# Altium schematic sheet Sheet1.SchDoc
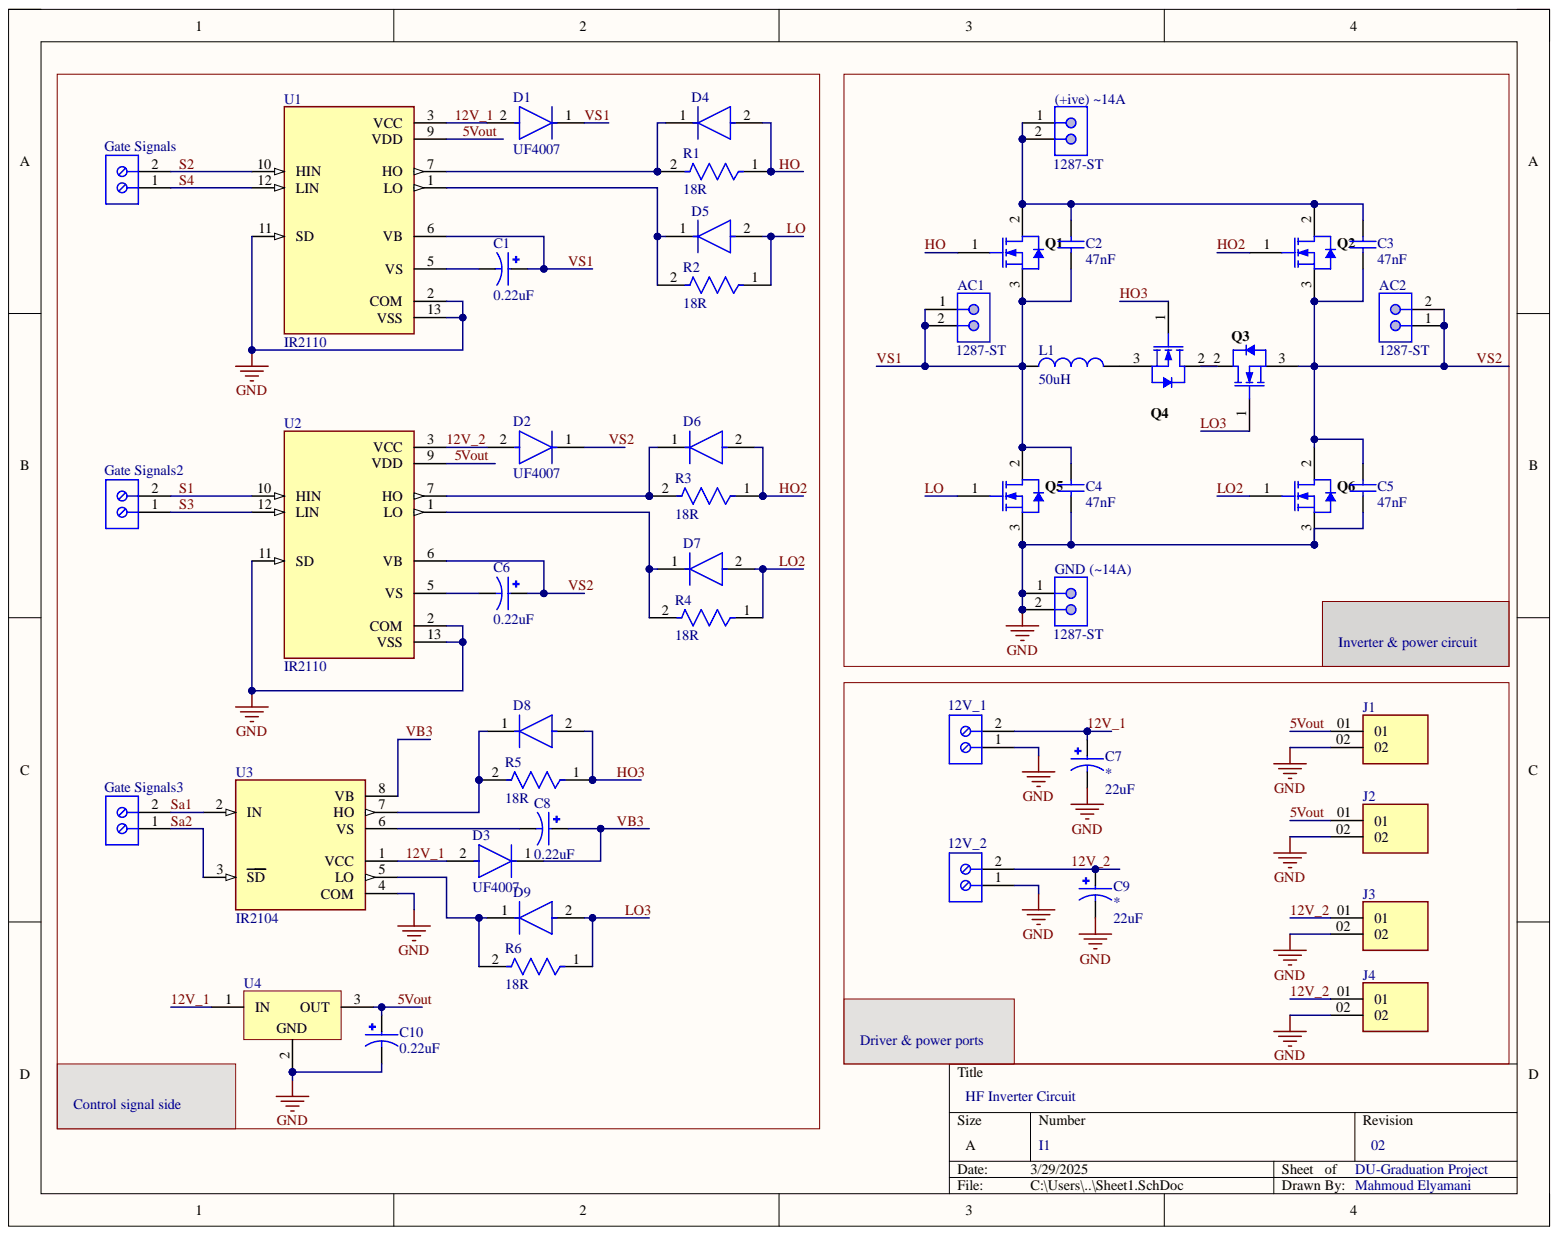
[diagram p0: Sheet1.SchDoc]

## Parts
12V_1  691213710002  [691213710002]  (value not drawn)
12V_2  691213710002  [691213710002]  (value not drawn)
(+ive)_~14A  1287-ST  [KSTN-1287-ST]
AC1  1287-ST  [KSTN-1287-ST]
AC2  1287-ST  [KSTN-1287-ST]
C1  value 0.22uF  [CAPPRD250W50D500H1100]  (hidden comment: EEUHD1HR22H)
C2  47nF  [WCAP-FTX2_L11W6H10_CS]
C3  47nF  [WCAP-FTX2_L11W6H10_CS]
C4  47nF  [WCAP-FTX2_L11W6H10_CS]
C5  47nF  [WCAP-FTX2_L11W6H10_CS]
C6  value 0.22uF  [CAPPRD250W50D500H1100]  (hidden comment: EEUHD1HR22H)
C7  22uF  [CAPPRD500W60D1000H2000]
C8  value 0.22uF  [CAPPRD250W50D500H1100]  (hidden comment: EEUHD1HR22H)
C9  22uF  [CAPPRD500W60D1000H2000]
C10  value 0.22uF  [CAPPRD250W50D500H1100]  (hidden comment: EEUHD1HR22H)
D1  UF4007  [FP-017AH-MFG]
D2  UF4007  [FP-017AH-MFG]
D3  UF4007  [FP-017AH-MFG]
D4  UF4007  [FP-017AH-MFG]  (value not drawn)
D5  UF4007  [FP-017AH-MFG]  (value not drawn)
D6  UF4007  [FP-017AH-MFG]  (value not drawn)
D7  UF4007  [FP-017AH-MFG]  (value not drawn)
D8  UF4007  [FP-017AH-MFG]  (value not drawn)
D9  UF4007  [FP-017AH-MFG]
Gate_Signals  691213710002  [691213710002]  (value not drawn)
Gate_Signals2  691213710002  [691213710002]  (value not drawn)
Gate_Signals3  691213710002  [691213710002]  (value not drawn)
GND_(~14A)  1287-ST  [KSTN-1287-ST]
J1  MTMM-102-04-T-S-170  [SAMTEC_MTMM-102-04-T-S-170]  (value not drawn)
J2  MTMM-102-04-T-S-170  [SAMTEC_MTMM-102-04-T-S-170]  (value not drawn)
J3  MTMM-102-04-T-S-170  [SAMTEC_MTMM-102-04-T-S-170]  (value not drawn)
J4  MTMM-102-04-T-S-170  [SAMTEC_MTMM-102-04-T-S-170]  (value not drawn)
L1  50uH  [7447071]
Q1  IRFP460PBF  [VISH-TO-247AC-3]  (value not drawn)
Q2  IRFP460PBF  [VISH-TO-247AC-3]  (value not drawn)
Q3  IRFP460PBF  [VISH-TO-247AC-3]  (value not drawn)
Q4  IRFP460PBF  [VISH-TO-247AC-3]  (value not drawn)
Q5  IRFP460PBF  [VISH-TO-247AC-3]  (value not drawn)
Q6  IRFP460PBF  [VISH-TO-247AC-3]  (value not drawn)
R1  18R  [FP-VR37-MFG]
R2  18R  [FP-VR37-MFG]
R3  18R  [FP-VR37-MFG]
R4  18R  [FP-VR37-MFG]
R5  18R  [FP-VR37-MFG]
R6  18R  [FP-VR37-MFG]
U1  IR2110  [DIP762W45P254L2019H533Q14]
U2  IR2110  [DIP762W45P254L2019H533Q14]
U3  IR2104  [DIP762W45P254L988H533Q8]
U4  L7824ABV  [TO220]  (value not drawn)

## Nets
GND: 12V_1.1 12V_2.1 C4.1 C5.1 C7.N C9.N C10.N GND_(~14A).1 GND_(~14A).2 J1.02 J2.02 J3.02 J4.02 Q5.3 Q6.3 U1.2(COM) U1.11(SD) U1.13(VSS) U2.2(COM) U2.11(SD) U2.13(VSS) U3.4(COM) U4.2
5Vout: C10.P J1.01 J2.01 U1.9(VDD) U2.9(VDD) U4.3
12V_1: 12V_1.2 C7.P D1.2 D3.2 U1.3(VCC) U3.1(VCC) U4.1
12V_2: 12V_2.2 C9.P D2.2 J3.01 J4.01 U2.3(VCC)
HO: D4.2 Q1.1 R1.1
HO2: D6.2 Q2.1 R3.1
HO3: D8.2 Q4.1 R5.1
LO: D5.2 Q5.1 R2.1
LO2: D7.2 Q6.1 R4.1
LO3: D9.2 Q3.1 R6.1
S1: Gate_Signals2.2 U2.10(HIN)
S2: Gate_Signals.2 U1.10(HIN)
S3: Gate_Signals2.1 U2.12(LIN)
S4: Gate_Signals.1 U1.12(LIN)
Sa1: Gate_Signals3.2 U3.2(IN)
Sa2: Gate_Signals3.1 U3.3(SD)
VB3: C8.P D3.1 U3.8(VB)
VS1: AC1.1 AC1.2 C1.P C2.1 C4.2 D1.1 L1.1 Q1.3 Q5.2 U1.6(VB)
VS2: AC2.1 AC2.2 C3.1 C5.2 C6.P D2.1 Q2.3 Q3.3 Q6.2 U2.6(VB)
Net(+ive) ~14A_1: (+ive)_~14A.1 (+ive)_~14A.2 C2.2 C3.2 Q1.2 Q2.2
NetC1_N: C1.N U1.5(VS)
NetC6_N: C6.N U2.5(VS)
NetC8_N: C8.N U3.6(VS)
NetD4_1: D4.1 R1.2 U1.7(HO)
NetD5_1: D5.1 R2.2 U1.1(LO)
NetD6_1: D6.1 R3.2 U2.7(HO)
NetD7_1: D7.1 R4.2 U2.1(LO)
NetD8_1: D8.1 R5.2 U3.7(HO)
NetD9_1: D9.1 R6.2 U3.5(LO)
NetL1_2: L1.2 Q4.3
NetQ3_2: Q3.2 Q4.2

## Library data of the parts
12V_1 12V_2 Gate_Signals Gate_Signals2 Gate_Signals3 = CMP-1502-02526-2: NexarPartId: 8917718; Current: 10A; Number of Contacts: 2; Number of Levels: 1; Pitch: 5.0038mm; Plating: Brass, Steel; Stripping Length: -7mm; Voltage: 300V; Wire Gauge (Max): 26AWG; Wire Gauge (Min): 16AWG; Color: Green; Component Kind: Standard; Contact Material: Brass; Contact Plating: Tin; Current Rating: 10A; Height: 10mm; Housing Material: Nylon; Lead Pitch: 5mm; Length: 8.3mm; Library Name: Altium Content Vault; Max Operating Temperature: 105°C; Min Operating Temperature: -40°C; Mount: Through Hole; Number of Pins: 2; Number of Positions Per Level: 2; Packaging: Box; REACH SVHC: Unknown; RoHS: Compliant; Termination: Screw; Voltage Rating: 300V; Width: 10.6mm; Wire/Cable Gauge: 26AWG
(+ive)_~14A AC1 AC2 GND_(~14A) = CMP-2000-05485-1: PC Sturdi-Mount Quick-Fit Terminal, Vertical, Male, 2-Pin THD, Bulk; Manufacturer: Keystone; Angle: Straight; Finish: Tin; Finish Plating: TinPlate; Insulation Type: Non-Insulated; Material, Contact: Brass; Mounting Hole Size: 0.052; Primary Type: QuickDisconnect; Standards: ULListed; Tab Fit: 0.25; Component Kind: Standard; Contact Plating: Tin; Datasheet URL: http://www.keyelco.com/product-pdf.cfm?p=1859; Datasheet Version: Rev. C, 08/2012; Diameter, Hole: 0.052in.; Gender: Male; Length, Overall: 0.552"; Library Name: Altium Content Vault; Manufacturer URL: http://www.keyelco.com/; Material: .032[.81]Brass; Mount Angle: Straight; Mounting: VERTICAL; Mounting Technology: ThroughHole; Orientation: VERTICAL-Sturdi-Mount; Package Description: 2-Leads Quick-Fit Terminal, Pitch 5.08 mm; Package Reference: 1287-ST; Package URL: http://www.keyelco.com/product-pdf.cfm?p=1859; Terminal Style: PCBMale; Terminal Type: Quick-Fit; Termination: Solder; Type: Tab; Width, Overall: 0.312"
C1 C6 C8 C10 = CMP-001-00083-1: Aluminum Electrolytic Capacitors, HD-A Series, 0.22uF +/- 20%, 50V, Radial lead type(5x11mm); MF: Panasonic; Availability: No availability data; Case/Package: RADIAL-2 Panasonic; Check_prices: https://www.snapeda.com/parts/EEUHD1HR22H/Panasonic/view-part/5796985/?ref=eda; Component Kind: Standard; Component Type: Standard; Design Item ID: EEUHD1HR22H; MP: EEUHD1HR22H; Pins: 2; Price: None; SnapEDA_Link: https://www.snapeda.com/parts/EEUHD1HR22H/Panasonic/view-part/5796985/?ref=snap; Source: EEUHD1HR22H.SchLib; Library Name: Elyamani's Personal Workspace
C2-C5 = CMP-03913-51400082-2: 890324022007CS WCAP-FTX2 Film Capacitor 15nF 275Vac; Dielectric: PP; Hole Diameter: 900µm; Insulation Resistance: 30GΩ; Pin Length: 4mm; Pitch: 7.5mm; Tolerance: 10%; Voltage Rating: 275V; Capacitance: 15nF; Case/Package: Radial; Component Kind: Standard; Dielectric Material: PP; Height: 10mm; Height - Seated (Max): 10.4902mm; Lead Pitch: 7.493mm; Length: 11mm; Library Name: Altium Content Vault; Max Operating Temperature: 105°C; Min Operating Temperature: -40°C; Mount: Through Hole; NexarPartId: 51400082; Packaging: Carton; REACH SVHC: Unknown; RoHS: Compliant; Termination: PC Pins; Voltage Rating (AC): 275V; Voltage Rating (DC): 275V; Width: 6mm
C7 C9 = CMP-001-00084-1: CAP ALUM 22UF 20% 160V RADIAL; MF: Panasonic; MP: EEU-ED2C220B; Availability: In Stock; Case/Package: RADIAL-2 Panasonic; Check_prices: https://www.snapeda.com/parts/EEU-ED2C220B/Panasonic/view-part/2339542/?ref=eda; Component Kind: Standard; Component Type: Standard; Design Item ID: EEUED2C220B; Pins: 2; Price: None; SnapEDA_Link: https://www.snapeda.com/parts/EEU-ED2C220B/Panasonic/view-part/2339542/?ref=snap; Source: EEUED2C220B.SchLib; Library Name: Elyamani's Personal Workspace
D1-D9 = CMP-2000-05028-2: DIODE GEN PURP 1KV 1A DO41; Manufacturer: ON Semiconductor; Capacitance: 17pF; Max Junction Temp: +150°C; Max Repetitive Reverse Voltage: 1000V; Max Surge Forward Current: 30A; Peak Reverse Current: 10uA; Value: 17pF; Automotive: No; Category: Diode; Component Kind: Standard; ComponentLink2Description: Datasheet; ComponentLink2URL: https://www.onsemi.com/pub/Collateral/UF4007-D.pdf; Device Class L1: Discrete Semiconductors; Device Class L2: Diodes; Device Class L3: Rectifier Diodes; Digikey Description: DIODE GEN PURP 1KV 1A DO41; Footprint Url: https://www.onsemi.com/pub/Collateral/017AH.PDF; Forward Current: 1A; Height: 2.72mm; Lead Free: Yes; Library Name: Altium Content Vault; Manufacturer Part Number: UF4007; Mouser Description: Rectifiers 1000V 1a Rectifier Glass Passivated; Octopart: https://octopart.com/uf4007-on+semiconductor-84331693; Package: 017AH; RoHS: TRUE; Temperature: -65°C to +150°C; Temperature Range High: +150°C; Temperature Range Low: -65°C
J1-J4 = CMP-002-00071-1: Availability: Unavailable; Case/Package: None; Component Kind: Standard; Component Type: Standard; Design Item ID: MTMM-102-04-T-S-170; MP: MTMM-102-04-T-S-170; Pins: 2; Price: None; Source: MTMM-102-04-T-S-170.SchLib; Library Name: Elyamani's Personal Workspace
L1 = CMP-03913-22803376-1: 7447071 470 µH ±20% Ferrite, Iron Powder Leaded Inductor, 3A Idc, 110mO Rdc WE-FI; Core Material: Composite, Ferrite; DC Resistance (DCR): 110mΩ; Inductance: 50µH; Max DC Current: 25A; Pin Length: 25mm; Shielding: Unshielded; Test Frequency: 10kHz; Ambient Temperature Range High: 65°C; Ambient Temperature Range Low: -40°C; Case/Package: Radial; Component Kind: Standard; Current Rating: 3A; Depth: 13mm; Diameter: 25mm; Inductance Tolerance: 20%; Lead Pitch: 11mm; Length: 25mm; Library Name: Altium Content Vault; Max Operating Temperature: 105°C; Min Operating Temperature: -40°C; Mount: Through Hole, Wire; NexarPartId: 22803376; Number of Pins: 2; Packaging: Bulk; REACH SVHC: Unknown; RMS Current (Irms): 3A; RoHS: Compliant; Series Resistance: 110mΩ; Termination: Through Hole; Tolerance: 20%; Width: 13.4mm
Q1-Q6 = CMP-2000-06478-1: N-Channel Power MOSFET, 500 V, -55 to 150 degC, 3-Pin FM (TO-247), RoHS, Tube; Manufacturer: Vishay; Continuous Drain Current Id: 20A; Drain Source Voltage Vds: 500V; No. of Pins: 3Pins; On Resistance Rds(on): 0.27ohm; Power Dissipation Pd: 280W; Rds(on) Test Voltage Vgs: 10V; SVHC: ToBeAdvised; Threshold Voltage Vgs: 4V; Transistor Case Style: TO-247AC; Transistor Polarity: NChannel; Automotive Qualification Standard: -; Component Kind: Standard; Datasheet URL: https://datasheet.ciiva.com/17070/0900766b8079122f-17070730.pdf; Datasheet Version: Rev. A, 07/2008; Library Name: Altium Content Vault; Manufacturer URL: http://www.vishay.com/; Mounting Technology: ThroughHole; MSL: -; Operating Temperature Max: 150°C; Package Description: 3-Pin Flange Mount Package, Body 15.58 x 4.945 mm, Pitch 5.46 mm; Package Reference: TO-247AC-3; Product Range: -
R1-R6 = CMP-02408-000054-1: RES 1.2M OHM 5% 1/2W AXIAL; Power Rating: 500mW; Resistance: 220Kohm; Temperature Coefficient: 200ppm/°C; Voltage Rating: 3.5kV; Case/Package: Conformal; Component Kind: Standard; Construction: Cylindrical; Contact Plating: Tin; Diameter: 4mm; Length: 10mm; Library Name: Altium Content Vault; Max Operating Temperature: 155°C; Military Standard: Not; Min Operating Temperature: -55°C; Mount: Through Hole; Packaging: Ammo Pack; Radiation Hardening: No; Reach SVHC Compliant: Yes; Resistance Tolerance: 5%; Resistor Type: Metal Film; Termination: Axial; Tolerance: 5%; Voltage Rating (DC): 3.5kV
U1 U2 = CMP-031-00007-1: Half-Bridge Gate Driver IC Non-Inverting 16-SOIC; MF: Infineon; Availability: In Stock; Case/Package: SOIC-16 International Rectifier; Check_prices: https://www.snapeda.com/parts/IR2110/Infineon+Technologies/view-part/?ref=eda; Component Kind: Standard; Component Type: Standard; Design Item ID: IR2110; MP: IR2110; Pins: 11; Price: None; Purchase-URL: https://pricing.snapeda.com/search/part/IR2110/?ref=eda; SnapEDA_Link: https://www.snapeda.com/parts/IR2110/Infineon+Technologies/view-part/?ref=snap; Source: IR2110.SchLib; Library Name: Elyamani's Personal Workspace
U3 = CMP-031-00008-1: Half-Bridge Gate Driver IC Non-Inverting 8-PDIP; MF: Infineon Technologies; Case/Package: DIP-8 Infineon; Check_prices: https://www.snapeda.com/parts/IR2104/Infineon/view-part/?ref=eda; Component Kind: Standard; Component Type: Standard; Design Item ID: IR2104; MP: IR2104; Pins: 8; SnapEDA_Link: https://www.snapeda.com/parts/IR2104/Infineon/view-part/?ref=snap; Source: IR2104.SchLib; Library Name: Elyamani's Personal Workspace
U4 = CMP-0244-00464-1: Positive Voltage Regulator, 24V, 3-Pin TO-220; Component Kind: Standard; ComponentLink1Description: Manufacturer URL; ComponentLink1URL: http://www.st.com; ComponentLink2Description: Datasheet; ComponentLink2URL: http://www.st.com/internet/com/TECHNICAL_RESOURCES/TECHNICAL_LITERATURE/DATASHEET/CD00000444.pdf; ComponentLink3Description: Package Specification; ComponentLink3URL: http://www.st.com/internet/com/TECHNICAL_RESOURCES/TECHNICAL_LITERATURE/PACKAGE_INFORMATION/CD00004649.pdf; DatasheetVersion: 16/11/2011; Library Name: Altium Content Vault; PackageDescription: TO, Thru-Hole, Vertical, Heatsink Mounted; 3 In-Line Leads; Pitch 2.54 mm; PackageReference: TO220; PackageVersion: ver3.0
